# Altium schematic sheet Multi_Power_250V.SchDoc
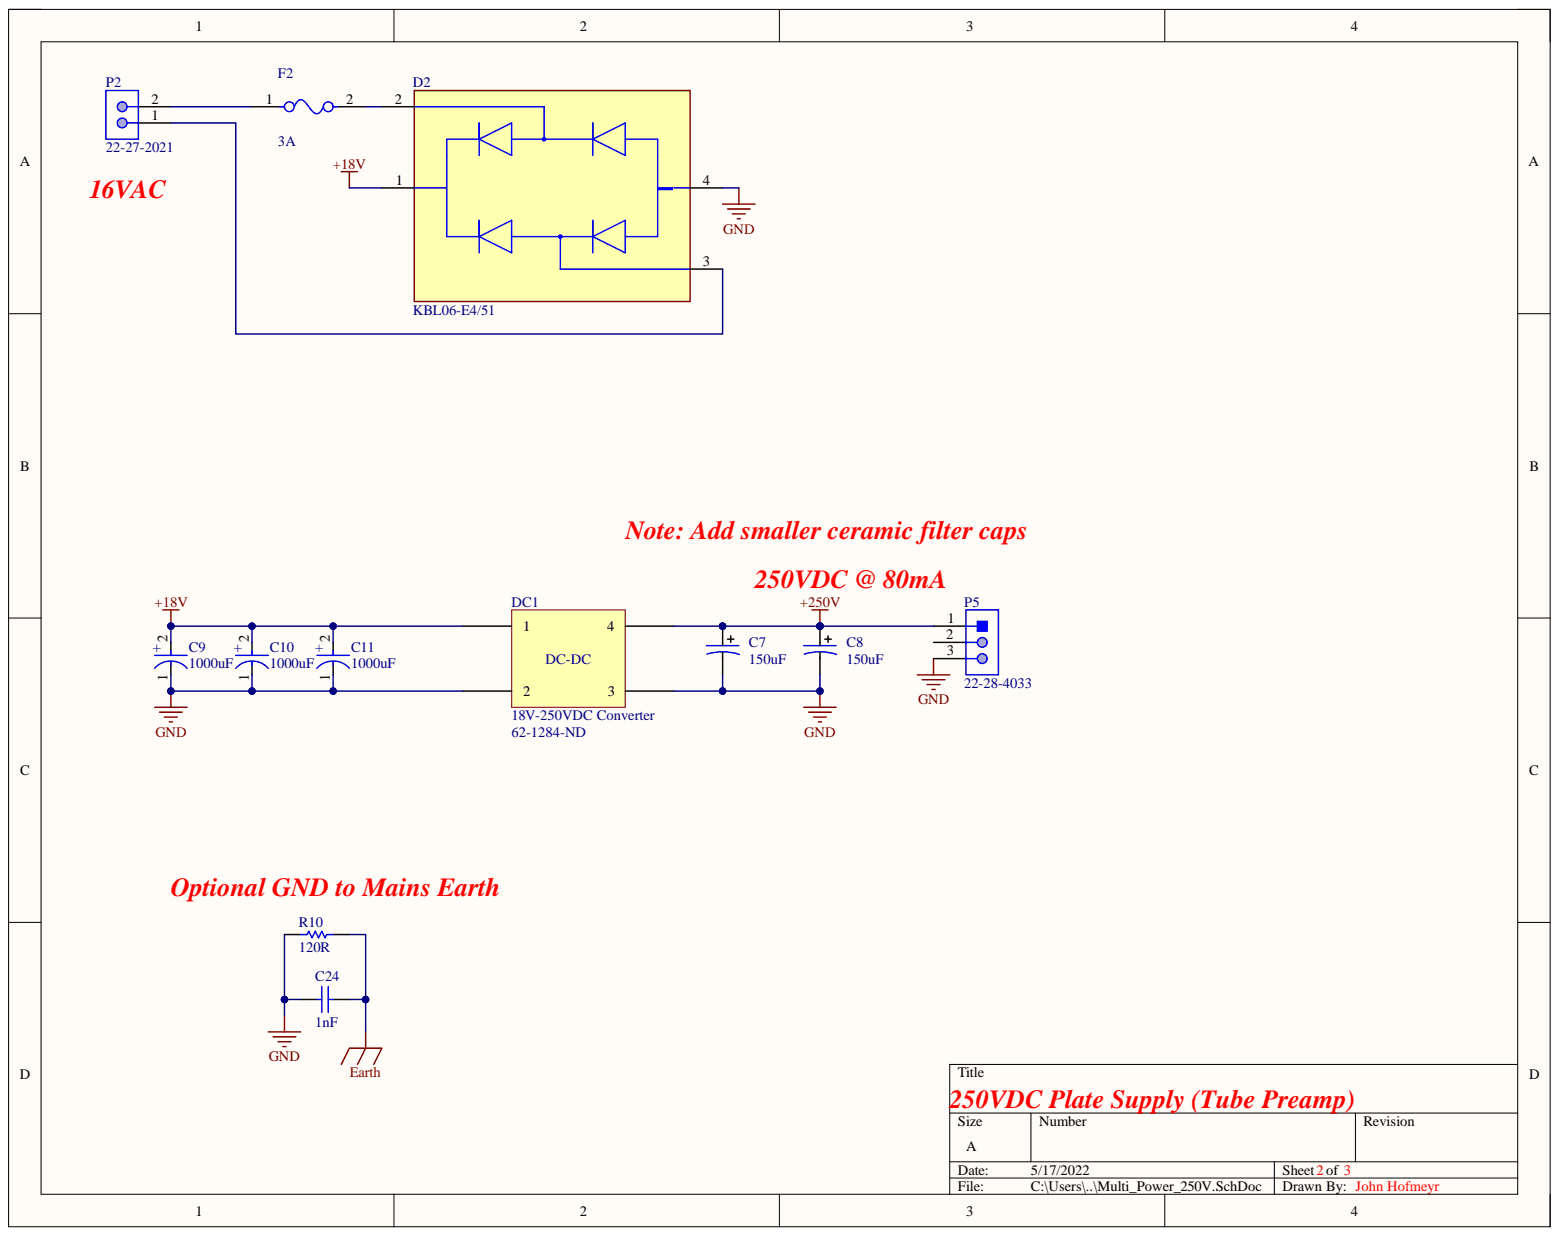
[diagram p0: Multi_Power_250V.SchDoc]

## Parts
C7  150uF  [C-1540]
C8  150uF  [C-1540]
C9  1000uF  [FP-ECA-1HM102-MFG]
C10  1000uF  [FP-ECA-1HM102-MFG]
C11  1000uF  [FP-ECA-1HM102-MFG]
C24  1nF  [CAPC2013X94X50ML20T25]
D2  KBL06-E4/51  [FP-KBL-MFG]
DC1  18V-250VDC Converter  Supplier Part Number 1: 62-1284-ND  [DC-DC Brick]
F2  3A  [FP-0466-MFG]
P2  22-27-2021  [MOLX-22-27-2021_V]
P5  22-28-4033  [MOLX-22-28-4033_V]
R10  120R  [RESC2013X50X40LL20T20]

## Nets
+18V: C9.2 C10.2 C11.2 D2.1 DC1.1
+250V: C7.1 C8.1 DC1.4 P5.1
Earth: C24.2 R10.1
GND: C7.2 C8.2 C9.1 C10.1 C11.1 C24.1 D2.4 DC1.2 DC1.3 P5.3 R10.2
NetD2_2: D2.2 F2.2
NetD2_3: D2.3 P2.1
NetF2_1: F2.1 P2.2

Not connected: P5 2

## Library data of the parts
C7 C8 = EPAG401ELL151ML40S: Component Kind: Standard; Library Name: Capacitor.SchLib; Supplier 1: Digi-Key; Supplier Part Number 1: EPAG401ELL151ML40S-ND
C9-C11 = CMP-05427-000008-1: CAP ALUM 1000UF 20% 50V RADIAL; Manufacturer: Panasonic; Manufacturer Part Number: ECA-1HM102; Dissipation Factor: 12%; Leakage Current: 500uA; Life: 2000Hours; Material: Aluminum; Ripple Current: 1050mA; Automotive: No; Capacitance: 1000uF; Category: Cap; Component Kind: Standard; ComponentLink2Description: Datasheet; ComponentLink2URL: https://na.industrial.panasonic.com/products/capacitors/aluminum-electrolytic-capacitors/lineup/aluminum-electrolytic-capacitors-radial-lead-type/series/83853/model/86899; Device Class L1: Passive Components; Device Class L2: Capacitors; Device Class L3: Aluminum Electrolytic Capacitors; Digikey Description: CAP ALUM 1000UF 20% 50V RADIAL; Height: 27mm; Ipc Land Pattern Name: CAPRD500W80D1250H2500; Lead Free: Yes; Library Name: Altium Content Vault; Octopart: https://octopart.com/eca1hm102-panasonic-2593463; Package: 0; RoHS: TRUE; Temperature: -40°C to +85°C; Temperature Range High: +85°C; Temperature Range Low: -40°C; Tolerance: 20%; Value: 1000uF; Voltage: 50V; Voltage Rating: 50V
C24 = CMP-1036-02979-2: Manufacturer: AVX Interconnect / Elco; Part Number: 08055C102KAT2A.; Component Kind: Standard; Library Name: Altium Content Vault
D2 = CMP-02540-000012-1: BRIDGE RECT 1PHASE 600V 4A KBL; Manufacturer: Vishay; Max Junction Temp: +150°C; Max Surge Forward Current: 200A; Peak Reverse Current: 5uA; Standoff Height: 0.2mm; Automotive: No; Category: Diode; Component Kind: Standard; ComponentLink2Description: Datasheet; ComponentLink2URL: http://www.vishay.com/docs/88655/kbl005.pdf; Device Class L1: Discrete Semiconductors; Device Class L2: Diodes; Device Class L3: Rectifier Diodes; Digikey Description: BRIDGE RECT 1PHASE 600V 4A KBL; Forward Current: 4A; Height: 16.3mm; Lead Free: Yes; Library Name: Altium Content Vault; Manufacturer Part Number: KBL06-E4/51; Max Repetitive Reverse Voltage: 600V; Mouser Description: Bridge Rectifiers 4.0 Amp 600 Volt; Octopart: https://octopart.com/kbl06-e4%2F51-vishay-42174180; Package: KBL; Reverse Voltage: 600V; RoHS: TRUE; Temperature: -50°C to +150°C; Temperature Range High: +150°C; Temperature Range Low: -50°C
DC1 = DC-DC Brick: Component Kind: Standard; Library Name: DC-DC.SchLib; Supplier 1: Digi-Key
F2 = CMP-08605-000054-1: FUSE BOARD MNT 3A 32VAC/VDC 1206; Manufacturer: Littelfuse; Manufacturer Part Number: 0466003.NR; Fuse Blow Speed: Fast; Fuse I2T: 0.576A2Sec; Fuse Interrupt Ac Current: 50A; Fuse Voltage Drop: 75.99mV; Max Fuse Ac Voltage: 32V; Resistance: 0.019Ω; Value: 0.019Ω; Automotive: No; Category: Fuse; Component Kind: Standard; ComponentLink2Description: Datasheet; ComponentLink2URL: https://www.littelfuse.com/~/media/electronics/datasheets/fuses/littelfuse_fuse_466_datasheet.pdf.pdf; Device Class L1: Circuit Protection; Device Class L2: Fuses; Device Class L3: unset; Digikey Description: FUSE BOARD MNT 3A 32VAC/VDC 1206; Fuse Current: 3A; Fuse Interrupt Dc Current: 50A; Height: 0.66mm; Lead Free: Yes; Library Name: Altium Content Vault; Max Fuse Dc Voltage: 32V; Mouser Description: Surface Mount Fuses 32V 3A VFA Slimline; Octopart: https://octopart.com/0466003.nr-littelfuse-565175; Package: 0466; RoHS: TRUE; Temperature: -55°C to +90°C; Temperature Range High: +90°C; Temperature Range Low: -55°C; Voltage Rating: 32V
P2 = CMP-2000-05537-1: Male Header, Pitch 2.54 mm, 1 x 2 Position, Height 11.7 mm, Tail Length 3.56 mm, 0 to 75 degC, RoHS, Bulk; Manufacturer: Molex; PartNumber: 0022272021; Current - Max: 4A; No. of Positions: 2; No. of Rows: 1; Package Version: Rev. BC, 02/2012; Pitch: 2.54mm; Voltage - Max: 250V; Component Kind: Standard; Contact material: Tinplatedbrass; Current rating: 4A; Datasheet URL: http://www.molex.com/pdm_docs/sd/022272021_sd.pdf; Datasheet Version: Rev. F, 06/2009; Housing material: Nylon(UL94V-0); Library Name: Altium Content Vault; Manufacturer URL: http://www.molex.com/; Mounting Technology: ThroughHole; Operating temperature: 0°Cto+75°C; Orientation: Vertical; Package Description: 2-Leads Header, Body 5.08 x 5.8 mm, Pitch 2.54 mm; Package Reference: 22-27-2021; Voltage rating: 250V
P5 = CMP-1676-00001-5: Male Header, Pitch 2.54 mm, 1 x 3 Position, Height 8.38 mm, Tail Length 3.18 mm, RoHS, Bulk; Manufacturer: Molex; Current Rating: 4 A; Rows: 1; Voltage Rating: 250 V; Colour: Black; Component Kind: Standard; ComponentLink1Description: Manufacturer URL; ComponentLink1URL: http://www.molex.com/; ComponentLink2Description: Datasheet; ComponentLink2URL: http://www.molex.com/pdm_docs/sd/022284033_sd.pdf; Contact Finish: Gold; Contact Material: Brass; Contact Plating: Gold; DatasheetVersion: Rev. G, 01/2012; Height: 8.38 mm; Lead Pitch: 0.1 inch; Length: 7.62 mm; Library Name: Altium Content Vault; Max Operating Temperature: 75 degC; Min Operating Temperature: 0 degC; Mount: Through Hole; Mounting Technology: Through Hole; Package Quantity: 1; PackageDescription: Male Header, Pitch 2.54 mm, 1 x 3 Position, Height 8.38 mm, Tail Length 3.18 mm; PackageReference: 22-28-4033; Packaging: Bulk; Pins: 3; REACH SVHC: Unknown; RoHSCompliant: true
R10 = CMP-2001-03236-1: Manufacturer: Susumu; Part Number: RR1220P-121-D; Component Kind: Standard; Library Name: Altium Content Vault
